# Altium schematic sheet Power.SchDoc(Power)
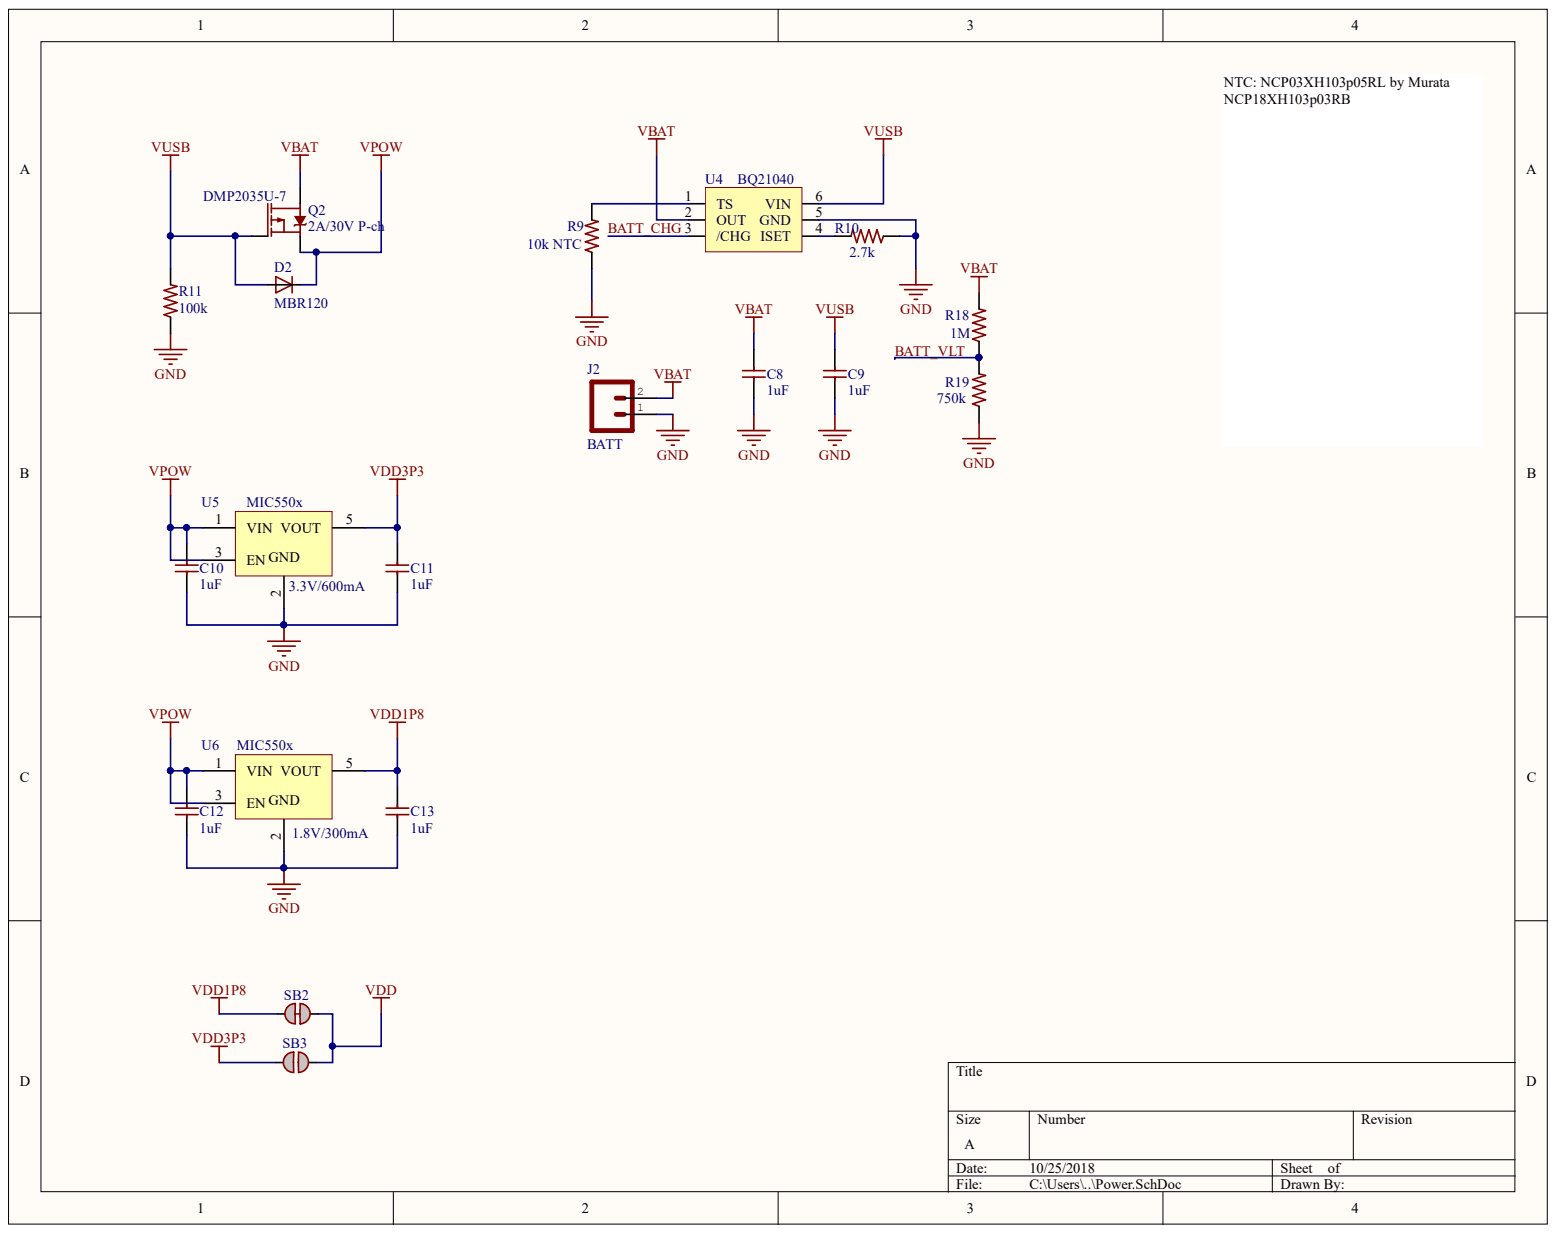
[diagram p0: Power.SchDoc]

## Parts
C8  1uF  [CAP0603]
C9  1uF  [CAP0603]
C10  1uF  [CAP0603]
C11  1uF  [CAP0603]
C12  1uF  [CAP0603]
C13  1uF  [CAP0603]
D2  MBR120  [SOD-123]
J2  BATT  [1X02]
Q2  2A/30V P-ch  [SOT23-3]
R9  10k NTC  [RES0603]
R10  2.7k  [RES0603]
R11  100k  [RES0603]
R18  1M  [RES0603]
R19  750k  [RES0603]
SB2  Shorted Solder Bridge  [Shorted Solder Bridge]  (value not drawn)
SB3  Open Solder Bridge  [Open Solder Bridge]  (value not drawn)
U4  BQ21040  [SOT23-6L]
U5  MIC550x  [SOT95P280X145-5N]
U6  MIC550x  [SOT95P280X145-5N]

## Nets
GND: C8.1 C9.1 C10.1 C11.1 C12.1 C13.1 J2.1 R9.1 R10.1 R11.1 R19.1 U4.5(GND) U5.2 U6.2
VBAT: C8.2 J2.2 Q2.3 R18.2 U4.2(OUT)
VDD: SB2.1 SB3.1
VDD1P8: C13.2 SB2.2 U6.5(VOUT)
VDD3P3: C11.2 SB3.2 U5.5(VOUT)
VPOW: C10.2 C12.2 D2.C Q2.2 U5.1(VIN) U5.3(EN_GND) U6.1(VIN) U6.3(EN_GND)
VUSB: C9.2 D2.A Q2.1 R11.2 U4.6(VIN)
BATT_CHG: U4.3(/CHG)
BATT_VLT: R18.1 R19.2
NetR9_2: R9.2 U4.1(TS)
NetR10_2: R10.2 U4.4(ISET)

## Library data of the parts
C8-C13 = Capacitor: Component Kind: Standard; Library Name: karo_components.IntLib
D2 = DIODESOD-123: Component Kind: Standard; Library Name: adafruit.IntLib
J2 = CONN_02: Component Kind: Standard; Library Name: SparkFun-Connectors.IntLib
Q2 = MOSFET_PCH-IRLML2244: PROD_ID: TRANS-11153; Component Kind: Standard; Library Name: SparkFun-DiscreteSemi.IntLib
R9-R11 R18 R19 = Resistor: Component Kind: Standard; Library Name: karo_components.IntLib
U4 = BQ21040: Battery charger; Component Kind: Standard; Library Name: karo_components.IntLib
SB2 = Shorted Solder Bridge: Component Kind: Standard; Library Name: karo_components.IntLib
SB3 = Open Solder Bridge: Component Kind: Standard; Library Name: karo_components.IntLib
U5 U6 = MIC550x: Component Kind: Standard; Library Name: karo_components.IntLib
